# Altium schematic sheet 06-Measurements.SchDoc
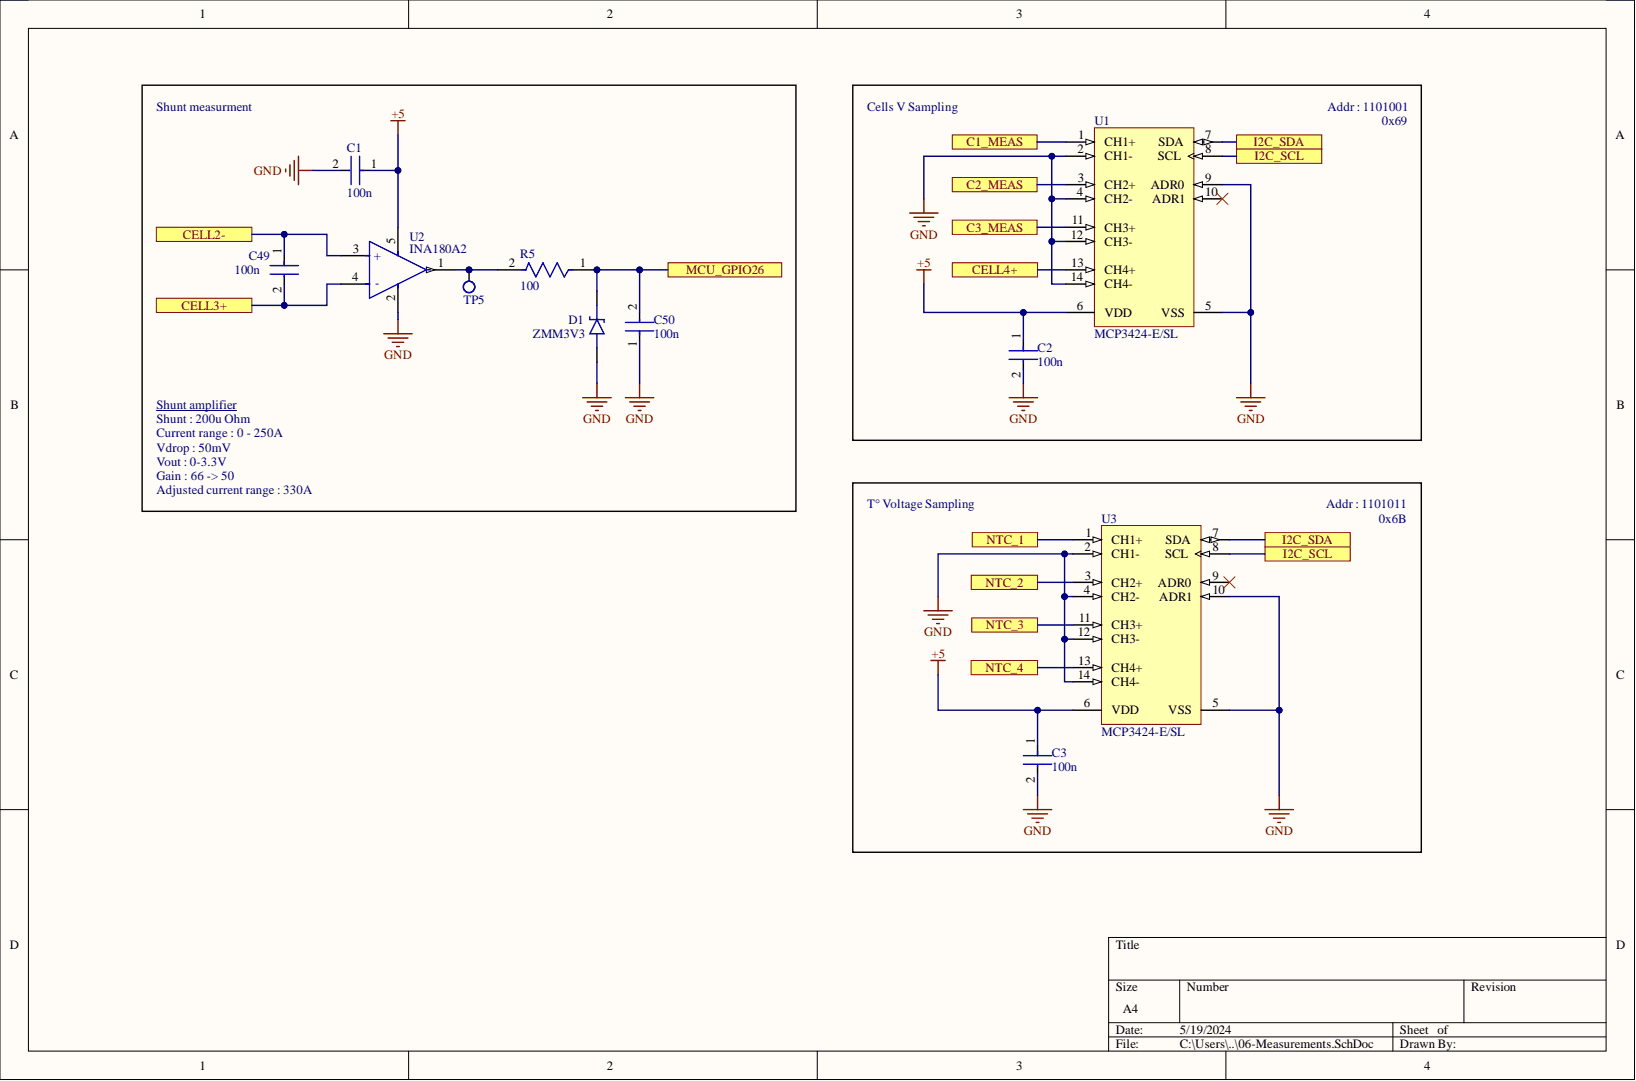
[diagram p0: 06-Measurements.SchDoc]

## Parts
C1  100n  [FP-CC0805-0_85-MFG]
C2  100n  [FP-CC0805-0_85-MFG]
C3  100n  [FP-CC0805-0_85-MFG]
C49  100n  [FP-CC0805-0_85-MFG]
C50  100n  [FP-CC0805-0_85-MFG]
D1  ZMM3V3  [DIOMELF3514N]
R5  100  [FP-RT0805-MFG]
TP5  Test point  [Test point-Footprint-1]  (value not drawn)
U1  MCP3424-E/SL  [SOIC-SL14_N]
U2  INA180A2  [FP-DBV0005A-IPC_C]
U3  MCP3424-E/SL  [SOIC-SL14_N]

## Ports
C1_MEAS, C2_MEAS, C3_MEAS, CELL2-, CELL3+, CELL4+, I2C_SCL, I2C_SDA, MCU_GPIO26, NTC_1, NTC_2, NTC_3, NTC_4

## Nets
+5: C1.1 C2.1 C3.1 U1.6(VDD) U2.5 U3.6(VDD)
GND: C1.2 C2.2 C3.2 C50.1 D1.2 U1.2(CH1-) U1.4(CH2-) U1.5(VSS) U1.9(ADR0) U1.12(CH3-) U1.14(CH4-) U2.2 U3.2(CH1-) U3.4(CH2-) U3.5(VSS) U3.10(ADR1) U3.12(CH3-) U3.14(CH4-)
C1_MEAS: U1.1(CH1+) ; port C1_MEAS
C2_MEAS: U1.3(CH2+) ; port C2_MEAS
C3_MEAS: U1.11(CH3+) ; port C3_MEAS
CELL2-: C49.1 U2.3(+) ; port CELL2-
CELL3+: C49.2 U2.4(-) ; port CELL3+
CELL4+: U1.13(CH4+) ; port CELL4+
I2C_SCL: U1.8(SCL) U3.8(SCL) ; port I2C_SCL
I2C_SDA: U1.7(SDA) U3.7(SDA) ; port I2C_SDA
MCU_GPIO26: C50.2 D1.1 R5.1 ; port MCU_GPIO26
NTC_1: U3.1(CH1+) ; port NTC_1
NTC_2: U3.3(CH2+) ; port NTC_2
NTC_3: U3.11(CH3+) ; port NTC_3
NTC_4: U3.13(CH4+) ; port NTC_4
NetR5_2: R5.2 TP5.1 U2.1

Not connected: U1 10(ADR1); U3 9(ADR0)

## Library data of the parts
C1-C3 C49 C50 = CMP-1036-04410-2: CAP CER 0.1UF 25V X7R 0805; Manufacturer: Yageo; Manufacturer Part Number: CC0805KRX7R8BB104; Capacitance: 100 nF; Material: Ceramic; Tolerance: 0.1; Value: 100 nF; Automotive: No; Category: Cap; Component Kind: Standard; ComponentLink2Description: Datasheet; ComponentLink2URL: http://www.yageo.com/documents/recent/UPY-GPHC_Y5V_6.3V-to-50V_8.pdf; Device Class L1: Passive Components; Device Class L2: Capacitors; Device Class L3: Ceramic Capacitors; Digikey Description: CAP CER 0.1UF 25V X7R 0805; Footprint Url: http://www.yageo.com/exep/pages/download/literatures/UPY-C_GEN_24.pdf; Height: 0.95mm; Ipc Land Pattern Name: CAPC200125X85; LCSC_Part_#: C49678; Lead Free: Yes; Library Name: Altium Content Vault; Mouser Description: Multilayer Ceramic Capacitors MLCC - SMD/SMT 100nF 25V X7R 10%; Octopart: https://octopart.com/cc0805krx7r8bb104-yageo-39467766; Package: CC0805-0.85; RoHS: TRUE; Temperature: -30°C to +85°C; Temperature Characteristic: X7R; Temperature Range High: +85°C; Temperature Range Low: -30°C; Voltage Rating: 25 V
D1 = CMP-004-00033-1: Zener ZMM3V3; Component Kind: Standard; Library Name: Clement Verda
R5 = CMP-03412-005354-1: RES SMD 4.7K OHM 0.5% 1/8W 0805; Manufacturer: Yageo; Manufacturer Part Number: RT0805DRE074K7L; Power Rating: 0.125W; Resistance: 4.7KΩ; Temperature Coefficient: 50 ppm/°C; Tolerance: 0.01; Value: 4.7KΩ; Voltage Rating: 150V; Automotive: No; Category: Res; Component Kind: Standard; ComponentLink2Description: Datasheet; ComponentLink2URL: http://www.yageo.com/documents/recent/PYu-RT_1-to-0.01_RoHS_L_9.pdf; Composition: Thin Film; Device Class L1: Passive Components; Device Class L2: Resistors; Device Class L3: Chip SMD Resistors; Digikey Description: RES SMD 4.7K OHM 0.5% 1/8W 0805; Footprint Url: http://www.yageo.com/exep/pages/download/literatures/PYu-R_Mount_10.pdf; Height: 0.6mm; Ipc Land Pattern Name: RESC200125X50; Lead Free: Yes; Library Name: Altium Content Vault; Octopart: https://octopart.com/rt0805dre074k7l-yageo-1242027; Package: RT0805; RoHS: TRUE; Series: RT; Temperature: -55°C to +155°C; Temperature Range High: +155°C; Temperature Range Low: -55°C
TP5 = CMP-002-00075-1: Component Kind: Standard; Description: test point; Library Name: Clement Verda
U1 U3 = CMP-0188-00426-2: 18-Bit, 4-Channel Analog-to-Digital Converter with I2C Interface and On-Board Reference, 14-Pin SOIC 150mil, Extended Temperature; Code_IPC: SOIC127P600-14; Code_JEDEC: MS-012-AB; Component Kind: Standard; ComponentLink1Description: Manufacturer URL; ComponentLink1URL: http://www.microchip.com/; ComponentLink2Description: Datasheet; ComponentLink2URL: http://ww1.microchip.com/downloads/en/DeviceDoc/22088c.pdf; ComponentLink3Description: Package Specification; ComponentLink3URL: http://www.microchip.com/stellent/groups/techpub_sg/documents/packagingspec/en012702.pdf; DatasheetVersion: revC, Aug-2009; LCSC_Part_#: C640884; Library Name: Altium Content Vault; PackageDescription: 14-Lead Plastic Small Outline (SL) - Narrow, 3.90mm Body [SOIC]; PackageReference: SOIC-SL14; PackageVersion: revBB, Aug-2009; RevisionNote: URLs added.
U2 = CMP-04895-000140-1: IC CURR SENSE 1 CIRCUIT SOT23-5; -3db Bandwidth: 350kHz; Input Bias Current: 100nA; Input Offset Voltage (Vos): 100uV; Number of Circuits: 1; Operating Supply Current: 260uA; Slew Rate: 2V/µs; Case/Package: SOT-23-5; Component Kind: Standard; LCSC_Part_#: C116203; Library Name: Altium Content Vault; Max Operating Temperature: 125°C; Min Operating Temperature: -40°C; Mount: Surface Mount; Packaging: Tape and Reel
